# Altium schematic sheet Startracker_Mainboard.SchDoc(Startracker_Mainboard)
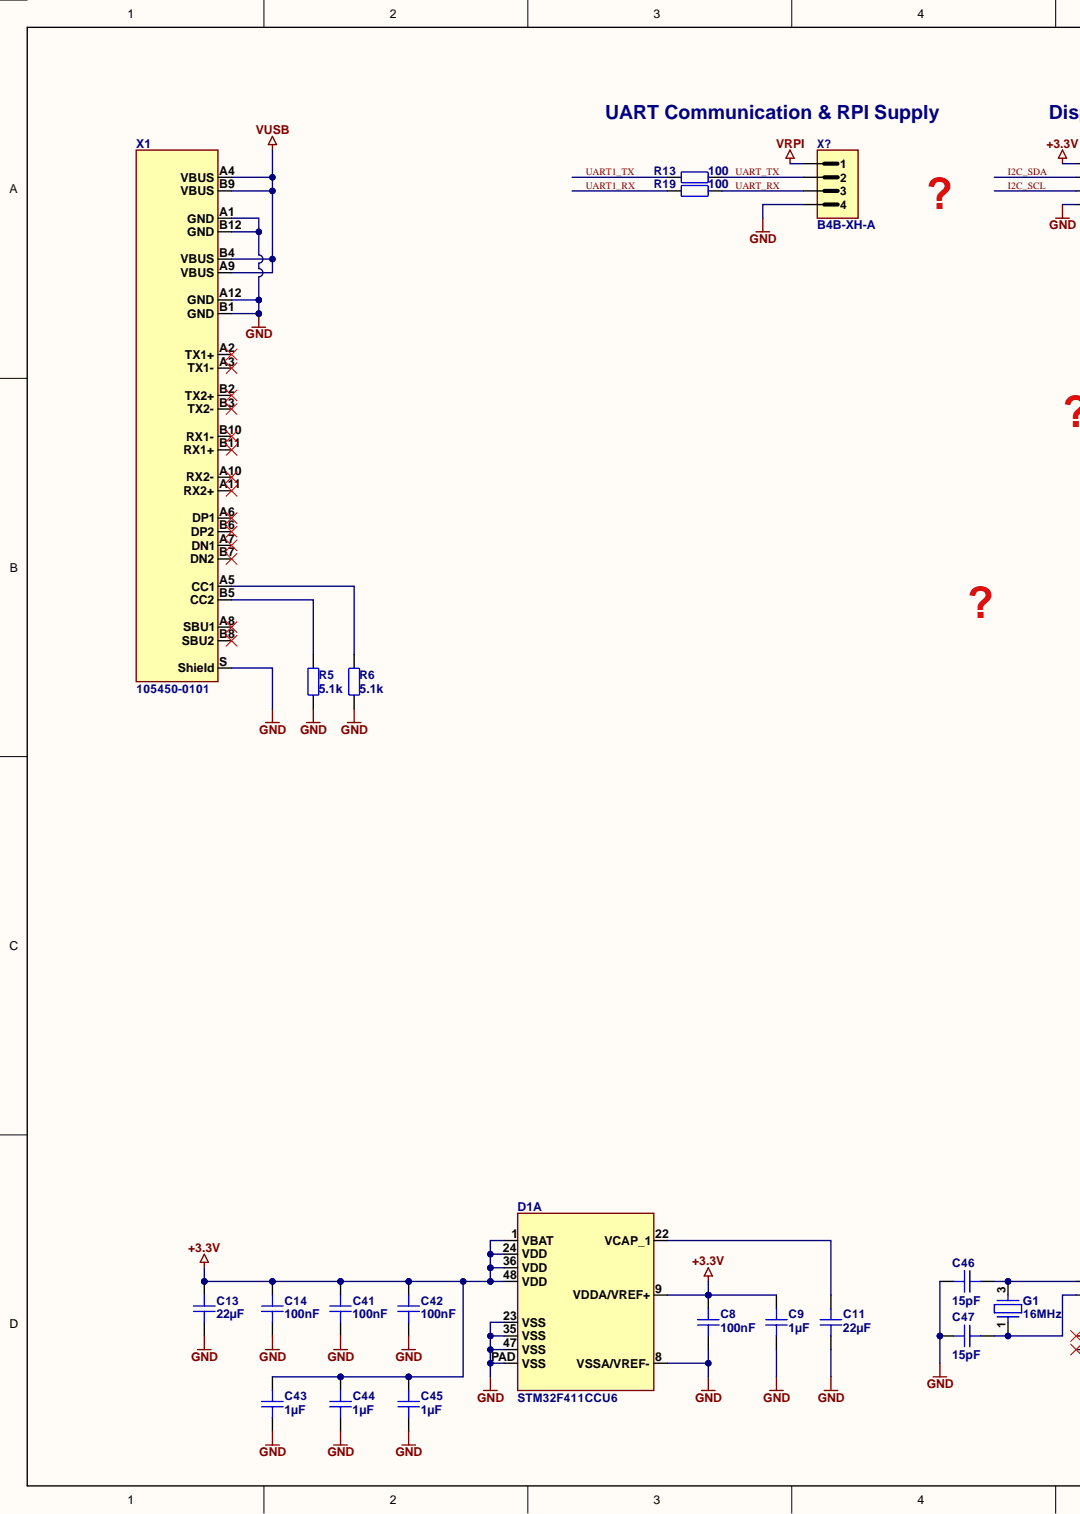
[diagram p0: Startracker_Mainboard.SchDoc, left]
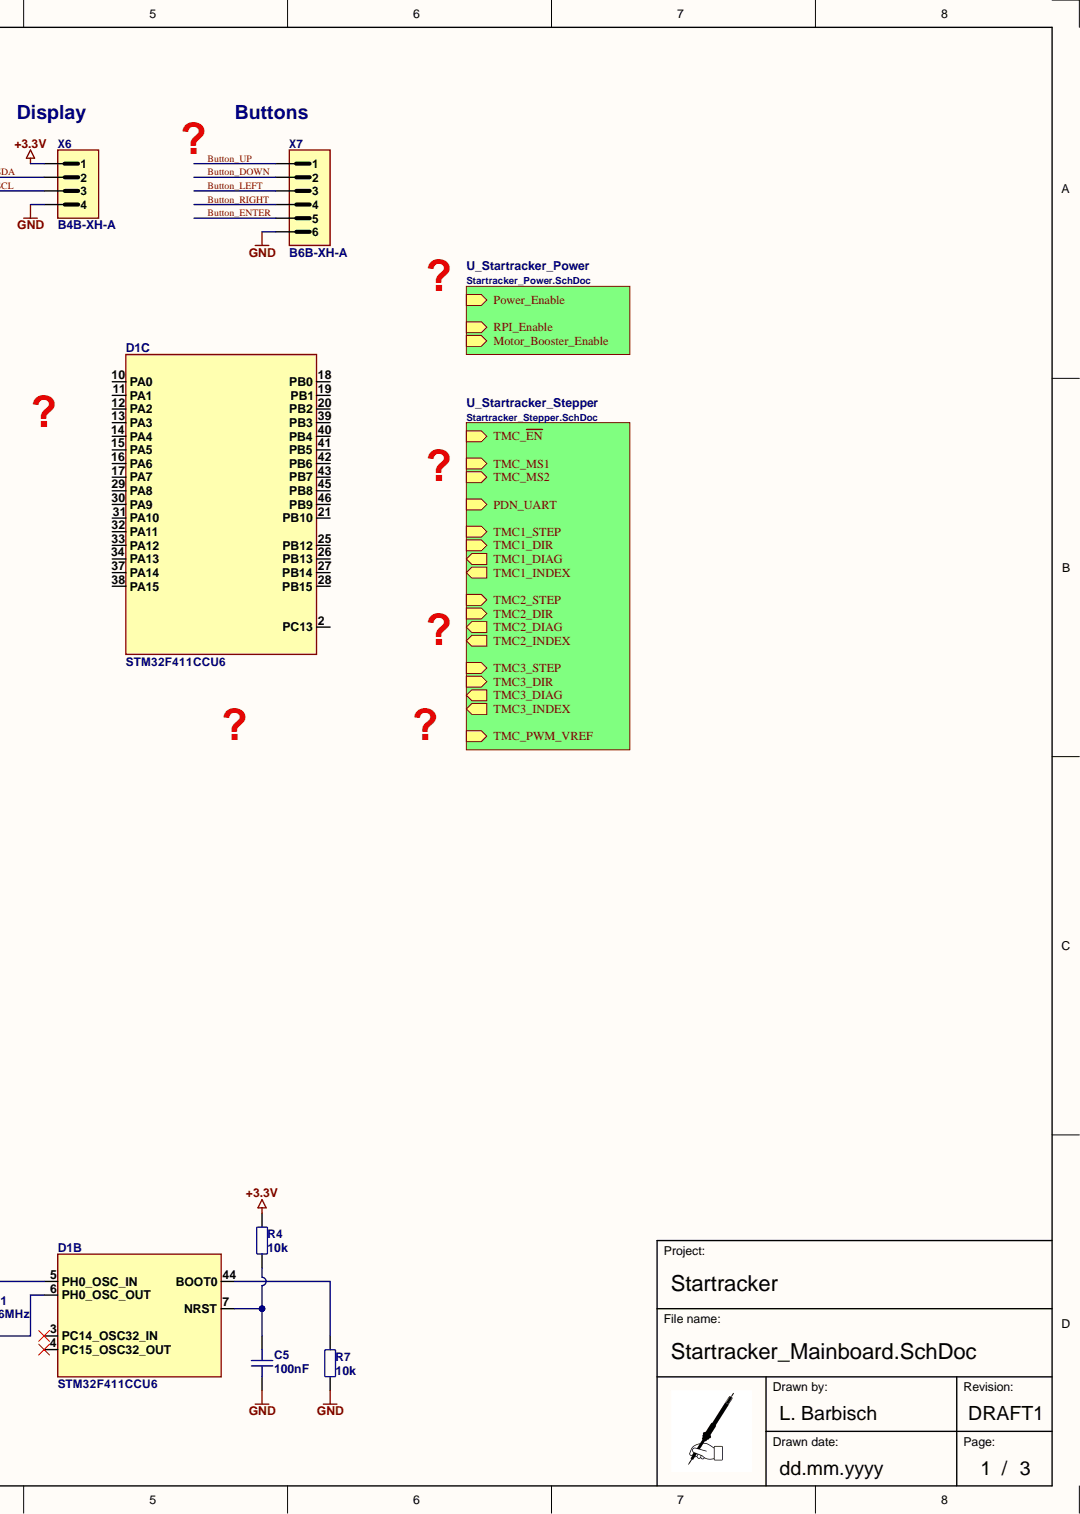
[diagram p1: Startracker_Mainboard.SchDoc, right]

## Parts
C5  value 100nF  [C-0603_1608_095_L_RF]  (hidden comment: Cap 100n 0603)
C8  value 100nF  [C-0603_1608_095_L_RF]  (hidden comment: Cap 100n 0603)
C9  value 1µF  [C-0603_1608_095_L_RF]  (hidden comment: Cap 1u 0603)
C11  value 22µF  [C-1206_3216_230_L_RF]  (hidden comment: Cap 22u 25V 10% 1206)
C13  value 22µF  [C-1206_3216_230_L_RF]  (hidden comment: Cap 22u 25V 10% 1206)
C14  value 100nF  [C-0603_1608_095_L_RF]  (hidden comment: Cap 100n 0603)
C41  value 100nF  [C-0603_1608_095_L_RF]  (hidden comment: Cap 100n 0603)
C42  value 100nF  [C-0603_1608_095_L_RF]  (hidden comment: Cap 100n 0603)
C43  value 1µF  [C-0603_1608_095_L_RF]  (hidden comment: Cap 1u 0603)
C44  value 1µF  [C-0603_1608_095_L_RF]  (hidden comment: Cap 1u 0603)
C45  value 1µF  [C-0603_1608_095_L_RF]  (hidden comment: Cap 1u 0603)
C46  value 15pF  [C-0603_1608_095_L_RF]  (hidden comment: Cap 15p 0603)
C47  value 15pF  [C-0603_1608_095_L_RF]  (hidden comment: Cap 15p 0603)
D1  STM32F411CCU6  [UFQFPN48_N_RF]  (parts A,B,C)
G1  16MHz  [G_3225_N_RF]
R4  value 10k  [R-0603_1608_050_L_RF]  (hidden comment: Res 10k 0603)
R5  value 5.1k  [R-0603_1608_050_L_RF]  (hidden comment: Res 5k1 0603)
R6  value 5.1k  [R-0603_1608_050_L_RF]  (hidden comment: Res 5k1 0603)
R7  value 10k  [R-0603_1608_050_L_RF]  (hidden comment: Res 10k 0603)
R13  value 100  [R-0603_1608_050_L_RF]  (hidden comment: Res 100R 0603)
R19  value 100  [R-0603_1608_050_L_RF]  (hidden comment: Res 100R 0603)
X1  105450-0101  [105450-0101]
X6  value B4B-XH-A  Manufacturer Part Number: B4B-XH-A  [X-0124X0057-R_M04_P0250_JST_B4B-XH-A]  (hidden comment: CONN HEADER XH 4POS THT)
X7  value B6B-XH-A  Manufacturer Part Number: B6B-XH-A  [X-0174X0057-R_M06_P0250_JST_B6B-XH-A]  (hidden comment: CONN HEADER XH 6POS THT)
X?  value B4B-XH-A  Manufacturer Part Number: B4B-XH-A  [X-0124X0057-R_M04_P0250_JST_B4B-XH-A]  (hidden comment: CONN HEADER XH 4POS THT)

## Nets
+3.3V: C8.1 C9.2 C13.2 C14.1 C41.1 C42.1 C43.2 C44.2 C45.2 D1.1(VBAT) D1.9(VDDA/VREF+) D1.24(VDD) D1.36(VDD) D1.48(VDD) R4.2 X6.1
GND: C5.2 C8.2 C9.1 C11.1 C13.1 C14.2 C41.2 C42.2 C43.1 C44.1 C45.1 C46.2 C47.2 D1.8(VSSA/VREF-) D1.23(VSS) D1.35(VSS) D1.47(VSS) D1.PAD(VSS) R5.1 R6.1 R7.1 X1.A1(GND) X1.A12(GND) X1.B1(GND) X1.B12(GND) X1.S(Shield) X6.4 X7.6 X?.4
VRPI: X?.1
VUSB: X1.A4(VBUS) X1.A9(VBUS) X1.B4(VBUS) X1.B9(VBUS)
Button_DOWN: X7.2
Button_ENTER: X7.5
Button_LEFT: X7.3
Button_RIGHT: X7.4
Button_UP: X7.1
I2C_SCL: X6.3
I2C_SDA: X6.2
UART1_RX: R19.1
UART1_TX: R13.1
UART_RX: R19.2 X?.3
UART_TX: R13.2 X?.2
NetC5_1: C5.1 D1.7(NRST) R4.1
NetC11_2: C11.2 D1.22(VCAP_1)
NetC46_1: C46.1 D1.5(PH0_OSC_IN) G1.3
NetC47_1: C47.1 D1.6(PH0_OSC_OUT) G1.1
NetD1_2: D1.2(PC13)
NetD1_3: D1.3(PC14_OSC32_IN)
NetD1_4: D1.4(PC15_OSC32_OUT)
NetD1_10: D1.10(PA0)
NetD1_11: D1.11(PA1)
NetD1_12: D1.12(PA2)
NetD1_13: D1.13(PA3)
NetD1_14: D1.14(PA4)
NetD1_15: D1.15(PA5)
NetD1_16: D1.16(PA6)
NetD1_17: D1.17(PA7)
NetD1_18: D1.18(PB0)
NetD1_19: D1.19(PB1)
NetD1_20: D1.20(PB2)
NetD1_21: D1.21(PB10)
NetD1_25: D1.25(PB12)
NetD1_26: D1.26(PB13)
NetD1_27: D1.27(PB14)
NetD1_28: D1.28(PB15)
NetD1_29: D1.29(PA8)
NetD1_30: D1.30(PA9)
NetD1_31: D1.31(PA10)
NetD1_32: D1.32(PA11)
NetD1_33: D1.33(PA12)
NetD1_34: D1.34(PA13)
NetD1_37: D1.37(PA14)
NetD1_38: D1.38(PA15)
NetD1_39: D1.39(PB3)
NetD1_40: D1.40(PB4)
NetD1_41: D1.41(PB5)
NetD1_42: D1.42(PB6)
NetD1_43: D1.43(PB7)
NetD1_44: D1.44(BOOT0) R7.2
NetD1_45: D1.45(PB8)
NetD1_46: D1.46(PB9)
NetR5_2: R5.2 X1.B5(CC2)
NetR6_2: R6.2 X1.A5(CC1)
NetX1_A2: X1.A2(TX1+)
NetX1_A3: X1.A3(TX1-)
NetX1_A6: X1.A6(DP1)
NetX1_A7: X1.A7(DN1)
NetX1_A8: X1.A8(SBU1)
NetX1_A10: X1.A10(RX2-)
NetX1_A11: X1.A11(RX2+)
NetX1_B2: X1.B2(TX2+)
NetX1_B3: X1.B3(TX2-)
NetX1_B6: X1.B6(DP2)
NetX1_B7: X1.B7(DN2)
NetX1_B8: X1.B8(SBU2)
NetX1_B10: X1.B10(RX1-)
NetX1_B11: X1.B11(RX1+)
Motor_Booster_Enable:
PDN_UART:
Power_Enable:
RPI_Enable:
TMC1_DIAG:
TMC1_DIR:
TMC1_INDEX:
TMC1_STEP:
TMC2_DIAG:
TMC2_DIR:
TMC2_INDEX:
TMC2_STEP:
TMC3_DIAG:
TMC3_DIR:
TMC3_INDEX:
TMC3_STEP:
TMC_~{EN}:
TMC_MS1:
TMC_MS2:
TMC_PWM_VREF:

## Library data of the parts
C5 C8 C14 C41 C42 = CMP-001-0037-3: Cap Cer SMD 100n 50V 10% 0603 X7R; Manufacturer: KEMET; Manufacturer Part Number: C0603C104K5RAC; GPV_Number: 1749.0075; MNL_Number: 22310507; Prefered: true; User: epph; Case / Package: 0603; ClassName: Surface_Assy; Component Kind: Standard; PartStatus: Release; Technology: SMT; Library Name: GPV Switzerland SA
C9 C43-C45 = CMP-001-0045-2: Cap Cer SMD 1u 16V 10% 0603 X7R; Manufacturer: AVX; Manufacturer Part Number: 0603YC105KAT*; GPV_Number: 1749.0125; MNL_Number: 22310613; Prefered: true; User: zupa; Case / Package: 0603; ClassName: Surface_Assy; Component Kind: Standard; ComponentLink1Description: Datasheet; ComponentLink1URL: https://datasheet.ciiva.com/11464/ccog-11464569.pdf; PartStatus: Release; Technology: SMT; Library Name: GPV Switzerland SA
C11 C13 = CMP-001-0137-2: Cap Cer SMD 22u 25V 10% 1206 X5R; Manufacturer: MURATA; Manufacturer Part Number: GRM31CR61E226KE15*; GPV_Number: 1751.0116; Prefered: false; User: zupa; Case / Package: 1206; ClassName: Surface_Assy; Component Kind: Standard; ComponentLink1Description: Datasheet; ComponentLink1URL: https://datasheet.ciiva.com/3778/100grm31cr61e226ke1523-3778430.pdf; PartStatus: Release; Technology: SMT; Library Name: GPV Switzerland SA
C46 C47 = CMP-001-0043-2: Cap Cer SMD 15p 50V 5% 0603 C0G; Manufacturer: AVX; Manufacturer Part Number: 06035A150JAT*; GPV_Number: 1749.0057; Prefered: true; User: zupa; Case / Package: 0603; ClassName: Surface_Assy; Component Kind: Standard; ComponentLink1Description: Datasheet; ComponentLink1URL: https://datasheet.ciiva.com/11464/ccog-11464569.pdf; PartStatus: Release; Technology: SMT; Library Name: GPV Switzerland SA
D1 = STM32F411CCU6: IC MCU Cortex-M4 256k Flash 128k RAM; Manufacturer: ST Microelectronics; Part_validated: No; GPV_Number: xxxx.xxxx; MNL_Number: xxxxxxxx; Case/Package: UFQFPN48; ClassName: Surface_Assy; Component Kind: Standard; Footprint_validated: No; Library Name: Global Library.SchLib; Manufacturer Part Number: STM32F411CCU6; PartStatus: New; System: -; Technology: SMT; Value: STM32F411CCU6
G1 = X322516MMB4SI: Crystal Oscillator 16MHz 10pF ±10ppm LCSC C112972; Manufacturer: Yangwing Tech; Part_validated: No; GPV_Number: xxxx.xxxx; MNL_Number: xxxxxxxx; Case/Package: 2532; ClassName: Surface_Assy; Component Kind: Standard; Footprint_validated: No; Library Name: Global Library.SchLib; Manufacturer Part Number: X322516MMB4SI; PartStatus: New; System: -; Technology: SMT; Value: 16MHz
R4 R7 = CMP-001-0241-3: Res SMD 10k 1% 0.1W 0603; Manufacturer: YAGEO; Manufacturer Part Number: RC0603FR-0710KL; GPV_Number: 1922.0100; MNL_Number: 15310022; Prefered: true; User: zupa; Case / Package: 0603; ClassName: Surface_Assy; Component Kind: Standard; ComponentLink1Description: Datasheet; ComponentLink1URL: https://datasheet.ciiva.com/11808/0900766b80f96399-11808280.pdf; PartStatus: Release; Technology: SMT; Library Name: GPV Switzerland SA
R5 R6 = CMP-001-0582-3: Res SMD 5k1 1% 0.1W 0603; Manufacturer: YAGEO; Manufacturer Part Number: RC0603FR-075K1L; GPV_Number: 1922.0144; Prefered: true; User: napa; Case / Package: 0603; ClassName: Surface_Assy; Component Kind: Standard; ComponentLink1Description: Datasheet; ComponentLink1URL: https://datasheet.ciiva.com/8895/pyu-rc0603-51-rohs-l-4-8895189.pdf; PartStatus: Release; Technology: SMT; Library Name: GPV Switzerland SA
R13 R19 = CMP-001-0240-3: Res SMD 100R 1% 0.1W 0603; Manufacturer: YAGEO; Manufacturer Part Number: RC0603FR-07100RL; GPV_Number: 1922.0102; MNL_Number: 15310004; Prefered: true; User: zupa; Case / Package: 0603; ClassName: Surface_Assy; Component Kind: Standard; ComponentLink1Description: Datasheet; ComponentLink1URL: https://datasheet.ciiva.com/11808/0900766b80f96399-11808280.pdf; PartStatus: Release; Technology: SMT; Library Name: GPV Switzerland SA
X1 = 105450-0101: CONN USB-C 16pin; Manufacturer: Molex; Part_validated: No; GPV_Number: xxxx.xxxx; MNL_Number: xxxxxxxx; System: Connector; Case/Package: USB-C; ClassName: Through-Hole_Assy; Component Kind: Standard; Footprint_validated: No; Library Name: Global Library.SchLib; Manufacturer Part Number: 105450-0101; PartStatus: New; Technology: SMT; Value: 105450-0101
X6 X? = CMP-00142-2: CONN HEADER  4POS_JST_B4B-XH; Manufacturer: JST; System: Connector; User: balu; ClassName: Through-Hole_Assy; Component Kind: Standard; PartStatus: Release; Technology: THT; Library Name: GPV Switzerland SA
X7 = CMP-00144-1: CONN HEADER  6POS_JST_B6B-XH; Manufacturer: JST; GPV_Number: 4401.2357; System: Connector; User: balu; ClassName: Through-Hole_Assy; Component Kind: Standard; PartStatus: Release; Technology: THT; Library Name: GPV Switzerland SA
